# Altium schematic sheet 04.TFT_LCD.SchDoc
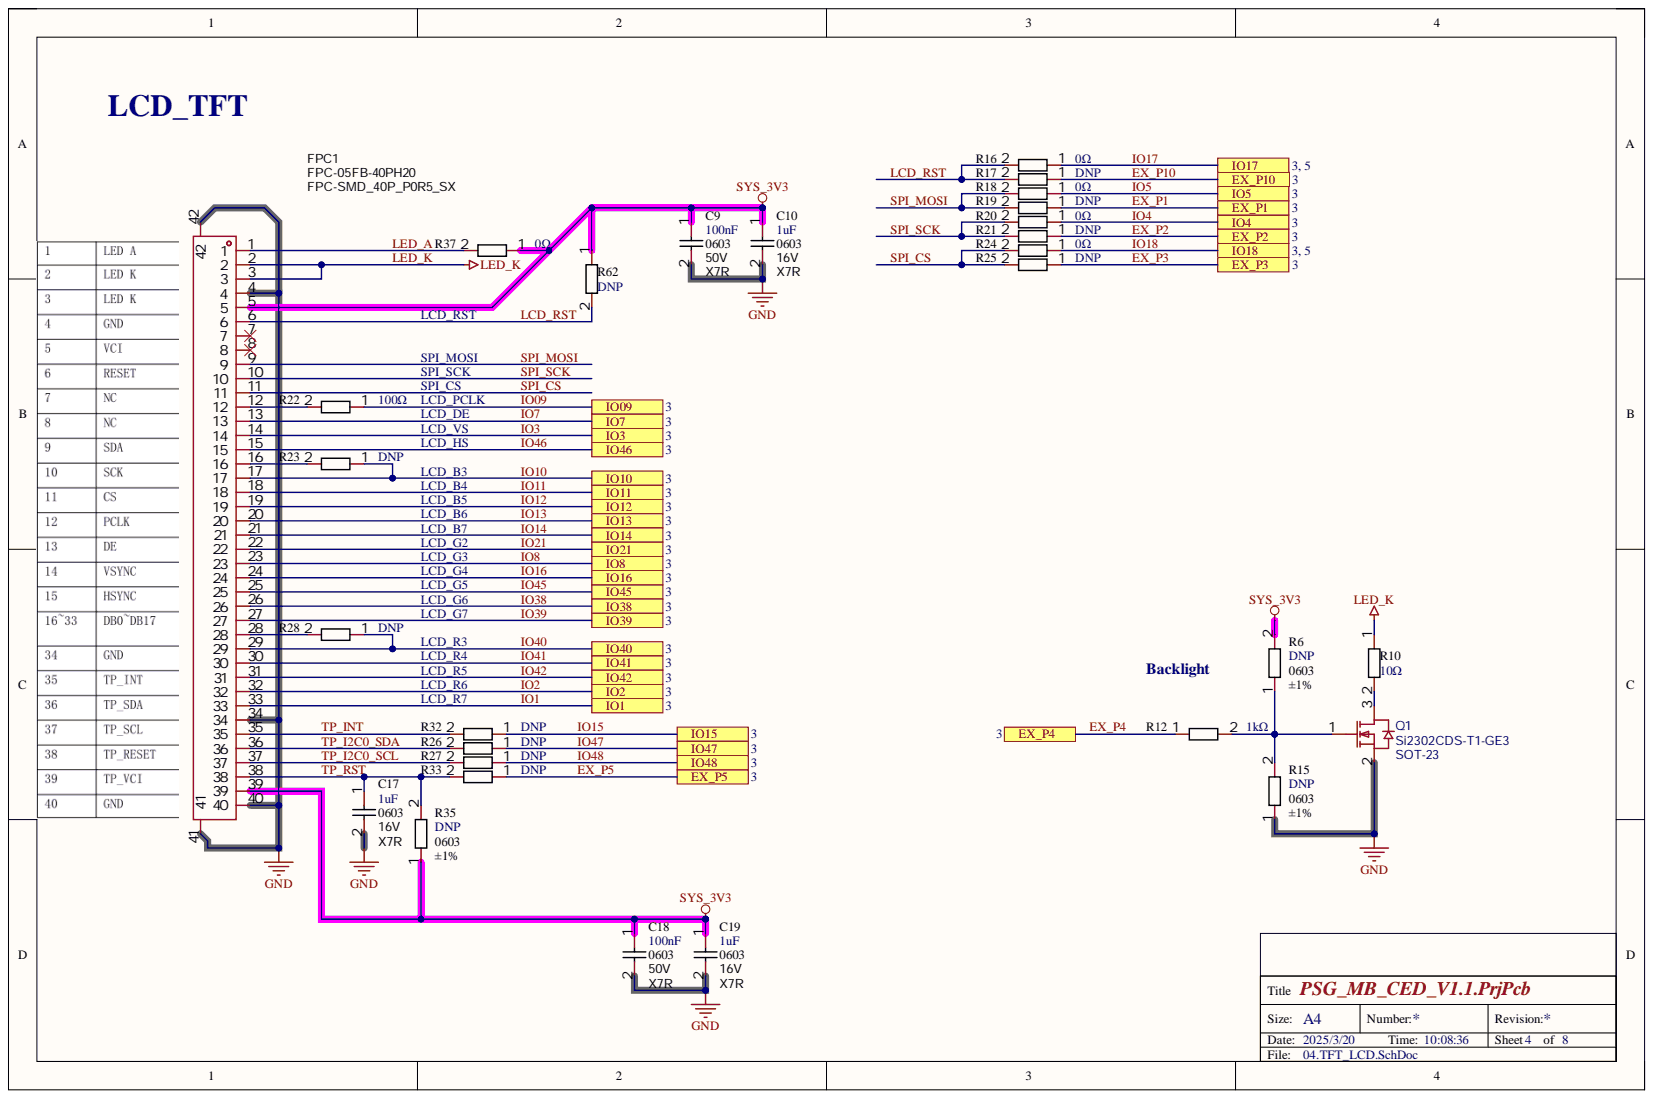
[diagram p0: 04.TFT_LCD.SchDoc]

## Parts
C9  100nF  Supplier Footprint: 0603  Temperature Coefficient: X7R  Voltage Rated: 50V  [C0603]
C10  1uF  Supplier Footprint: 0603  Temperature Coefficient: X7R  Voltage Rated: 16V  [C0603]
C17  1uF  Supplier Footprint: 0603  Temperature Coefficient: X7R  Voltage Rated: 16V  [C0603]
C18  100nF  Supplier Footprint: 0603  Temperature Coefficient: X7R  Voltage Rated: 50V  [C0603]
C19  1uF  Supplier Footprint: 0603  Temperature Coefficient: X7R  Voltage Rated: 16V  [C0603]
FPC1  FPC-05FB-40PH20  [FPC-SMD_40P_P0R5_SX]
Q1  Si2302CDS-T1-GE3  [SOT-23]
R6  DNP  Supplier Footprint: 0603  Tolerance: ±1%  [R0603]
R10  10Ω  [R0603]
R12  1kΩ  [R0603]
R15  DNP  Supplier Footprint: 0603  Tolerance: ±1%  [R0603]
R16  0Ω  [R0603]
R17  DNP  [R0603]
R18  0Ω  [R0603]
R19  DNP  [R0603]
R20  0Ω  [R0603]
R21  DNP  [R0603]
R22  100Ω  [R0603]
R23  DNP  [R0603]
R24  0Ω  [R0603]
R25  DNP  [R0603]
R26  DNP  [R0603]
R27  DNP  [R0603]
R28  DNP  [R0603]
R32  DNP  [R0603]
R33  DNP  [R0603]
R35  DNP  Supplier Footprint: 0603  Tolerance: ±1%  [R0603]
R37  0Ω  [R0603]
R62  DNP  [R0603]

## Ports
EX_P1, EX_P2, EX_P3, EX_P4, EX_P5, EX_P10, IO1, IO2, IO3, IO4, IO5, IO7, IO8, IO09, IO10, IO11, IO12, IO13, IO14, IO15, IO16, IO17, IO18, IO21, IO38, IO39, IO40, IO41, IO42, IO45, IO46, IO47, IO48

## Nets
GND: C9.2 C10.2 C17.2 C18.2 C19.2 FPC1.4 FPC1.34 FPC1.40 FPC1.41 FPC1.42 Q1.2 R15.1
SYS_3V3: C9.1 C10.1 C18.1 C19.1 FPC1.5 FPC1.39 R6.2 R35.1 R37.1 R62.1
EX_P1: R19.1 ; port EX_P1
EX_P2: R21.1 ; port EX_P2
EX_P3: R25.1 ; port EX_P3
EX_P4: R12.1 ; port EX_P4
EX_P5: R33.1 ; port EX_P5
EX_P10: R17.1 ; port EX_P10
IO1: FPC1.33 ; port IO1
IO2: FPC1.32 ; port IO2
IO3: FPC1.14 ; port IO3
IO4: R20.1 ; port IO4
IO5: R18.1 ; port IO5
IO7: FPC1.13 ; port IO7
IO8: FPC1.23 ; port IO8
IO09: R22.1 ; port IO09
IO10: FPC1.17 R23.1 ; port IO10
IO11: FPC1.18 ; port IO11
IO12: FPC1.19 ; port IO12
IO13: FPC1.20 ; port IO13
IO14: FPC1.21 ; port IO14
IO15: R32.1 ; port IO15
IO16: FPC1.24 ; port IO16
IO17: R16.1 ; port IO17
IO18: R24.1 ; port IO18
IO21: FPC1.22 ; port IO21
IO38: FPC1.26 ; port IO38
IO39: FPC1.27 ; port IO39
IO40: FPC1.29 R28.1 ; port IO40
IO41: FPC1.30 ; port IO41
IO42: FPC1.31 ; port IO42
IO45: FPC1.25 ; port IO45
IO46: FPC1.15 ; port IO46
IO47: R26.1 ; port IO47
IO48: R27.1 ; port IO48
LCD_RST: FPC1.6 R16.2 R17.2 R62.2
LED_A: FPC1.1 R37.2
LED_K: FPC1.2 FPC1.3 R10.1
SPI_CS: FPC1.11 R24.2 R25.2
SPI_MOSI: FPC1.9 R18.2 R19.2
SPI_SCK: FPC1.10 R20.2 R21.2
TP_I2C0_SCL: FPC1.37 R27.2
TP_I2C0_SDA: FPC1.36 R26.2
TP_INT: FPC1.35 R32.2
TP_RST: C17.1 FPC1.38 R33.2 R35.2
NetFPC1_12: FPC1.12 R22.2
NetFPC1_16: FPC1.16 R23.2
NetFPC1_28: FPC1.28 R28.2
NetQ1_1: Q1.1 R6.1 R12.2 R15.2
NetQ1_3: Q1.3 R10.2

Not connected: FPC1 7 8

## Library data of the parts
C9 C18 = CC0603JRNPO9BN120: Manufacturer: YAGEO(国巨); Add into BOM: yes; Device: CC0603KRX7R9BB104; Name: 贴片电容; Tolerance: ±10%; Component Kind: Standard; Convert to PCB: yes; Datasheet: https://atta.szlcsc.com/upload/public/pdf/source/20180910/C282072_33301C7F631E79921B82A8DAC6BCC5B3.pdf; JLCPCB Part Class: Basic Part; LCSC Part Name: 100nF ±10% 50V; Manufacturer Part: CC0603KRX7R9BB104; Supplier: LCSC; Supplier Part: C14663; Symbol: CC0603KRX7R9BB104; Value: 100nF
C10 C17 C19 = CC0603JRNPO9BN120: Manufacturer: YAGEO(国巨); Add into BOM: yes; Device: CC0603KRX7R7BB105; Name: 贴片电容; Tolerance: ±10%; Component Kind: Standard; Convert to PCB: yes; Datasheet: https://atta.szlcsc.com/upload/public/pdf/source/20180910/C282072_33301C7F631E79921B82A8DAC6BCC5B3.pdf; JLCPCB Part Class: Extended Part; LCSC Part Name: 1uF ±10% 16V; Manufacturer Part: CC0603KRX7R7BB105; Supplier: LCSC; Supplier Part: C106248; Symbol: CC0603KRX7R7BB105; Value: 1uF
FPC1 = FPC-05FB-40PH20: Manufacturer: XUNPU(讯普); Add into BOM: yes; FFC, FCB Thickness: 0.3mm; Locking Feature: 翻盖式; Number of Contacts: 40P; Pitch: 0.5mm; Component Kind: Standard; Contact Type: 双侧触点/上下接; Convert to PCB: yes; Datasheet: https://atta.szlcsc.com/upload/public/pdf/source/20210922/C2856837_A0FF02895414764A7F2E525E58748FC4.pdf; Height Above Board: 2mm; JLCPCB Part Class: Extended Part; LCSC Part Name: 间距:0.5mm P数:40P 翻盖式 双侧触点/上下接; Manufacturer Part: FPC-05FB-40PH20; Mounting Type: 卧贴; Operating Temperature Range: -25℃~+85℃; PCB3D: FPC-SMD_40P-P0.50_XUNPU_FPC-05FB-40PH20; Supplier: LCSC; Supplier Footprint: FPC-SMD_40P_P0R5_SX; Supplier Part: C2856837; Symbol: FPC-05FB-40PH20; Value: FPC-05FB-40PH20
Q1 = Si2302CDS-T1-GE3: Manufacturer: VISHAY(威世); Add into BOM: yes; Continuous Drain Current (Id): 2.9A; Drain Source On Resistance (RDS(on)@Vgs,Id): 57mΩ@4.5V,3.6A; Drain Source Voltage (Vdss): 20V; Gate Threshold Voltage (Vgs(th)@Id): 850mV@250uA; Input Capacitance (Ciss@Vds): 320pF@10V; Power Dissipation (Pd): 550mW; Reverse Transfer Capacitance (Crss@Vds): 5pF@10V; Total Gate Charge (Qg@Vgs): 3.5nC@4.5V; Type: 1个N沟道; Component Kind: Standard; Convert to PCB: yes; Datasheet: https://atta.szlcsc.com/upload/public/pdf/source/20121215/1457706570095.pdf; Device: Si2302CDS-T1-GE3; JLCPCB Part Class: Extended Part; LCSC Part Name: 1个N沟道 耐压:20V 电流:2.9A; Manufacturer Part: Si2302CDS-T1-GE3; Operating Temperature: -55℃~+150℃; PCB3D: SOT-23-3P_L2.9-W1.3-H1.0-LS2.4-P0.95; Supplier: LCSC; Supplier Footprint: SOT-23; Supplier Part: C10488; Symbol: Si2302CDS-T1-GE3
R6 = 0603WAF1003T5E: Manufacturer: YAGEO(国巨); Add into BOM: yes; Device: RC0603FR-07100KL; Name: 贴片电阻; Overload Voltage (Max): 75V; Power(Watts): 100mW; Temperature Coefficient: ±100ppm/℃; Component Kind: Standard; Convert to PCB: yes; Datasheet: https://atta.szlcsc.com/upload/public/pdf/source/20140324/1457707028752.pdf; JLCPCB Part Class: Extended Part; LCSC Part Name: 厚膜电阻 100kΩ ±1% 100mW; Manufacturer Part: RC0603FR-07100KL; Operating Temperature Range: -55℃~+155℃; Supplier: LCSC; Supplier Part: C14675; Symbol: RC0603FR-07100KL; Type: 厚膜电阻; Value: DNP
R10 = 0603WAF1003T5E: Manufacturer: UNI-ROYAL(厚声); Add into BOM: yes; Device: 0603WAF100JT5E; Name: 贴片电阻; Overload Voltage (Max): 75V; Power(Watts): 100mW; Temperature Coefficient: ±400ppm/℃; Component Kind: Standard; Convert to PCB: yes; Datasheet: https://atta.szlcsc.com/upload/public/pdf/source/20200306/C422600_1E6D84923E4A46A82E41ADD87F860B5C.pdf; JLCPCB Part Class: Basic Part; LCSC Part Name: 10Ω ±1% 100mW 厚膜电阻; Manufacturer Part: 0603WAF100JT5E; Operating Temperature Range: -55℃~+155℃; Supplier: LCSC; Supplier Part: C22859; Symbol: 0603WAF100JT5E; Type: 厚膜电阻; Value: 10Ω
R12 = 0603WAF1003T5E: Manufacturer: YAGEO(国巨); Add into BOM: yes; Device: RC0603FR-071KL; Name: 贴片电阻; Overload Voltage (Max): 75V; Power(Watts): 100mW; Temperature Coefficient: ±100ppm/℃; Component Kind: Standard; Convert to PCB: yes; Datasheet: https://atta.szlcsc.com/upload/public/pdf/source/20180316/70C0E2BDAE7FCC62C531A5A58D202EE1.pdf; JLCPCB Part Class: Extended Part; LCSC Part Name: 厚膜电阻 1kΩ ±1% 100mW; Manufacturer Part: RC0603FR-071KL; Operating Temperature Range: -55℃~+155℃; Supplier: LCSC; Supplier Part: C22548; Symbol: RC0603FR-071KL; Type: 厚膜电阻; Value: 1kΩ
R15 R35 = 0603WAF1003T5E: Manufacturer: YAGEO(国巨); Add into BOM: yes; Device: RC0603FR-0710KL; Name: 贴片电阻; Overload Voltage (Max): 75V; Power(Watts): 100mW; Temperature Coefficient: ±100ppm/℃; Component Kind: Standard; Convert to PCB: yes; Datasheet: https://atta.szlcsc.com/upload/public/pdf/source/20180927/C295883_E8D83085EA81BD7DE0B2B681138C15EE.pdf; JLCPCB Part Class: Extended Part; LCSC Part Name: 厚膜电阻 10kΩ ±1% 100mW; Manufacturer Part: RC0603FR-0710KL; Operating Temperature Range: -55℃~+155℃; Supplier: LCSC; Supplier Part: C98220; Symbol: RC0603FR-0710KL; Type: 厚膜电阻; Value: DNP
R16-R21 R23-R28 R32 R33 R37 R62 = 0603WAF1003T5E: Manufacturer: YAGEO(国巨); Add into BOM: yes; Device: RC0603FR-070RL; Name: 贴片电阻; Overload Voltage (Max): 75V; Power(Watts): 100mW; Component Kind: Standard; Convert to PCB: yes; Datasheet: https://atta.szlcsc.com/upload/public/pdf/source/20180316/70C0E2BDAE7FCC62C531A5A58D202EE1.pdf; JLCPCB Part Class: Extended Part; LCSC Part Name: 厚膜电阻 0Ω ±1% 100mW; Manufacturer Part: RC0603FR-070RL; Operating Temperature Range: -55℃~+155℃; Supplier: LCSC; Supplier Part: C100044; Symbol: RC0603FR-070RL; Temperature Coefficient: -; Type: 厚膜电阻
  R16 R18 R20 R24 R37: Value: 0Ω
  R17 R19 R21 R23 R25-R28 R32 R33 R62: Value: DNP
R22 = 0603WAF1003T5E: Manufacturer: YAGEO(国巨); Add into BOM: yes; Device: RC0603FR-07100RL; Name: 贴片电阻; Overload Voltage (Max): 75V; Power(Watts): 100mW; Temperature Coefficient: ±100ppm/℃; Component Kind: Standard; Convert to PCB: yes; Datasheet: https://atta.szlcsc.com/upload/public/pdf/source/20180316/70C0E2BDAE7FCC62C531A5A58D202EE1.pdf; JLCPCB Part Class: Extended Part; LCSC Part Name: 厚膜电阻 100Ω ±1% 100mW; Manufacturer Part: RC0603FR-07100RL; Operating Temperature Range: -55℃~+155℃; Supplier: LCSC; Supplier Part: C105588; Symbol: RC0603FR-07100RL; Type: 厚膜电阻; Value: 100Ω
